# Altium schematic sheet HAQuDA_upper_PCB_schematic_Ver_2.SchDoc
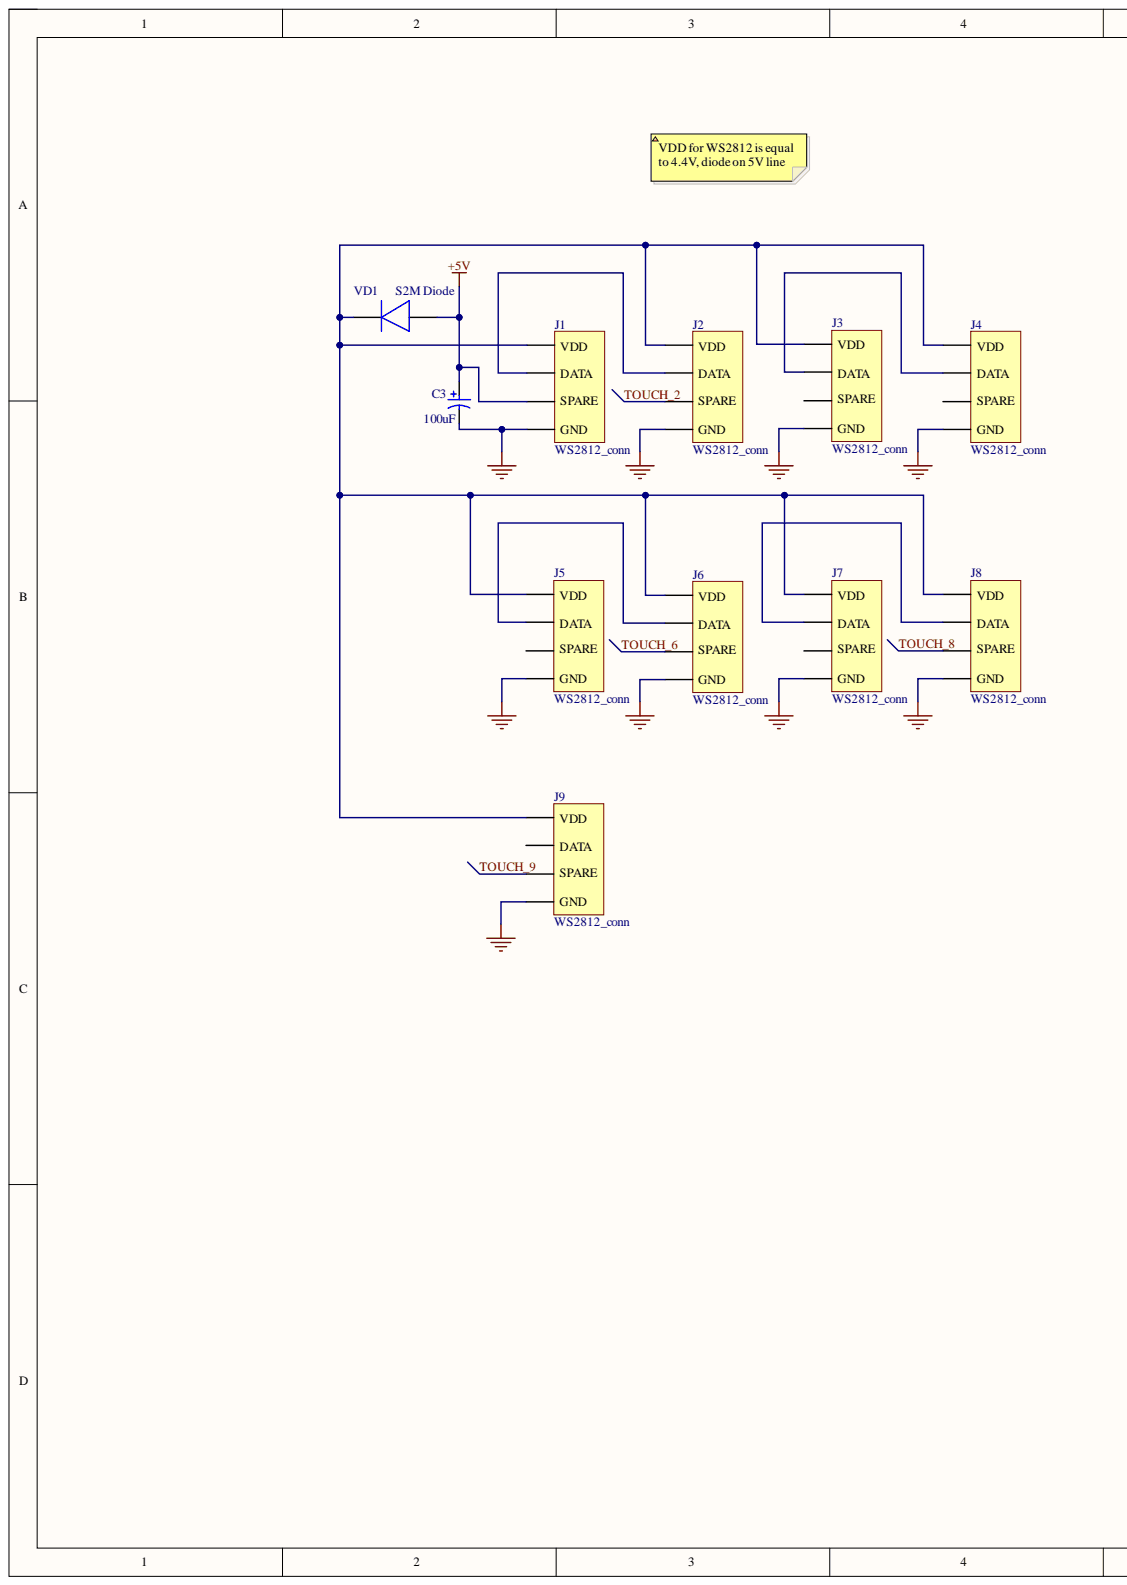
[diagram p0: HAQuDA_upper_PCB_schematic_Ver_2.SchDoc, left]
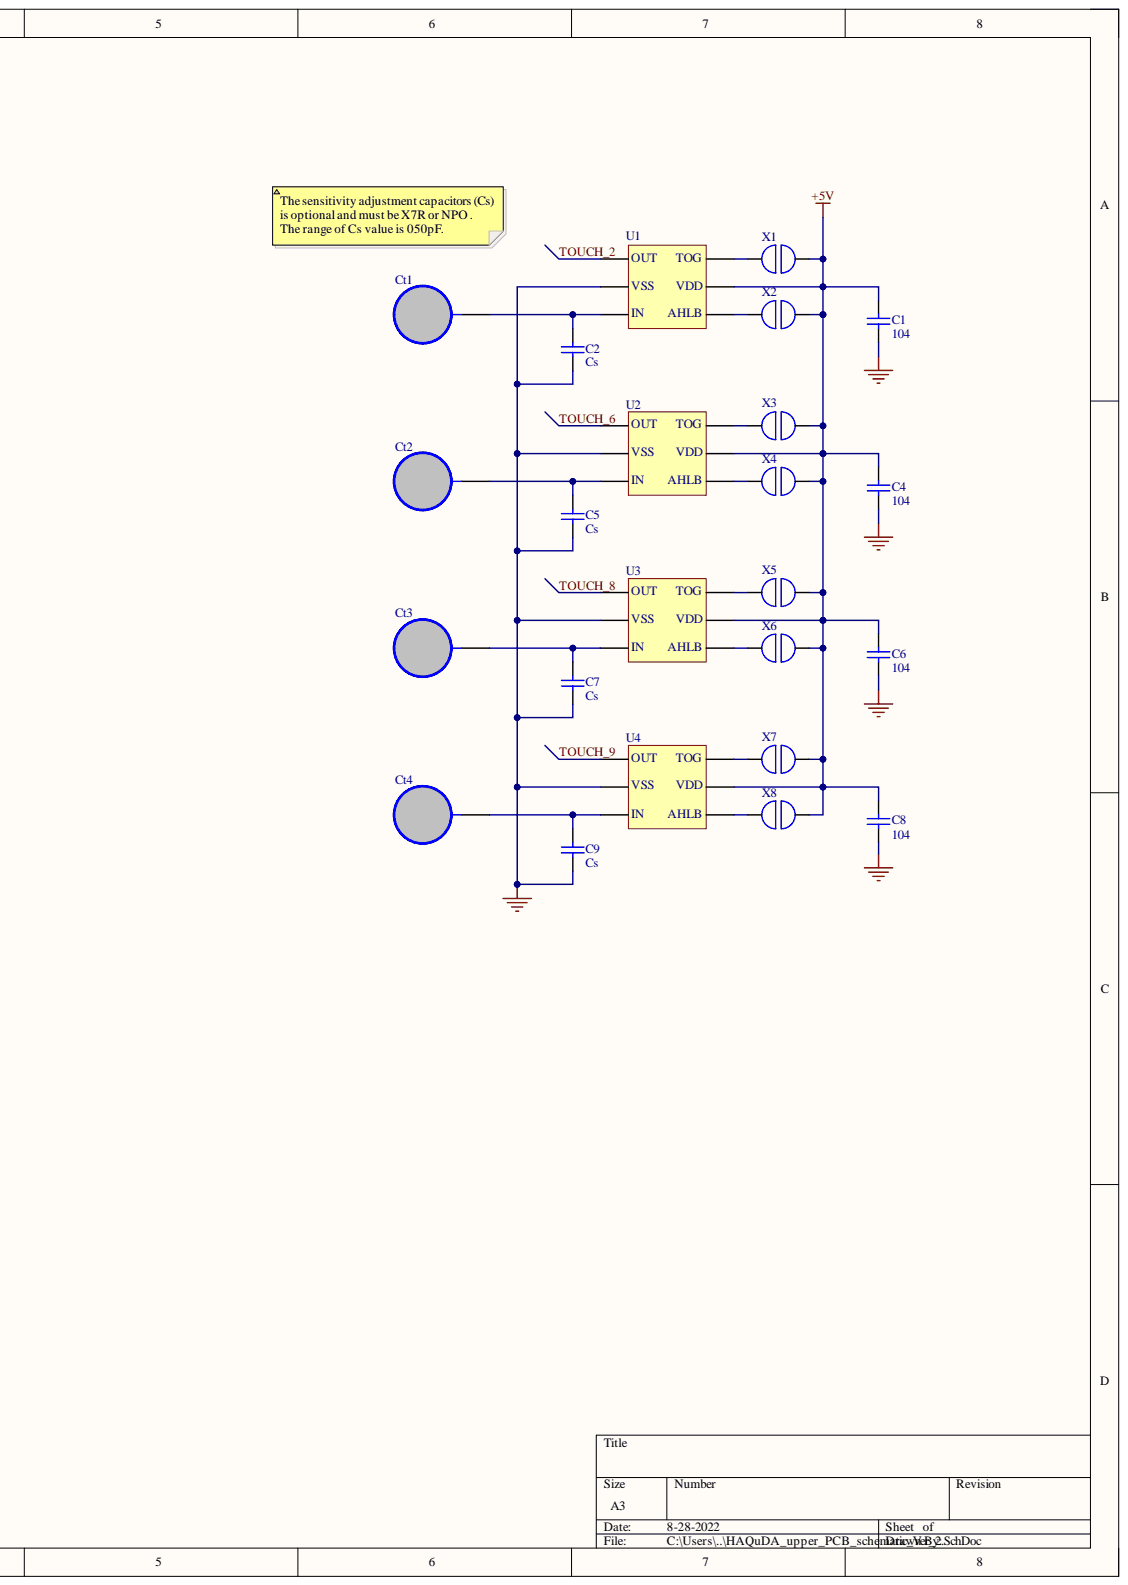
[diagram p1: HAQuDA_upper_PCB_schematic_Ver_2.SchDoc, right]

## Parts
C1  104  [CAPC2013X70X45LL10T25]
C2  Cs  [CAPC2013X70X45LL10T25]
C3  100uF  [CAPPR60-350-1500X800X1650]
C4  104  [CAPC2013X70X45LL10T25]
C5  Cs  [CAPC2013X70X45LL10T25]
C6  104  [CAPC2013X70X45LL10T25]
C7  Cs  [CAPC2013X70X45LL10T25]
C8  104  [CAPC2013X70X45LL10T25]
C9  Cs  [CAPC2013X70X45LL10T25]
Ct1  TOUCH 1  [Touch pad]  (value not drawn)
Ct2  TOUCH 6  [Touch pad]  (value not drawn)
Ct3  TOUCH 8  [Touch pad]  (value not drawn)
Ct4  TOUCH 9  [Touch pad]  (value not drawn)
J1  WS2812_conn  [WS2812_conn]
J2  WS2812_conn  [WS2812_conn]
J3  WS2812_conn  [WS2812_conn]
J4  WS2812_conn  [WS2812_conn]
J5  WS2812_conn  [WS2812_conn]
J6  WS2812_conn  [WS2812_conn]
J7  WS2812_conn  [WS2812_conn]
J8  WS2812_conn  [WS2812_conn]
J9  WS2812_conn  [WS2812_conn]
U1  Touch pad  IC  [TTP223-BA6]  (value not drawn)
U2  Touch pad  IC  [TTP223-BA6]  (value not drawn)
U3  Touch pad  IC  [TTP223-BA6]  (value not drawn)
U4  Touch pad  IC  [TTP223-BA6]  (value not drawn)
VD1  S2M Diode  [S2M Dioide ext pad]
X1  TOG  [SOLDER JUMPER]  (value not drawn)
X2  AHLB  [SOLDER JUMPER]  (value not drawn)
X3  TOG  [SOLDER JUMPER]  (value not drawn)
X4  AHLB  [SOLDER JUMPER]  (value not drawn)
X5  TOG  [SOLDER JUMPER]  (value not drawn)
X6  AHLB  [SOLDER JUMPER]  (value not drawn)
X7  TOG  [SOLDER JUMPER]  (value not drawn)
X8  AHLB  [SOLDER JUMPER]  (value not drawn)

## Nets
+5V: C1.2 C3.1 C4.2 C6.2 C8.2 J1.3(SPARE) U1.5(VDD) U2.5(VDD) U3.5(VDD) U4.5(VDD) VD1.2 X1.2 X2.2 X3.2 X4.2 X5.2 X6.2 X7.2 X8.2
GND: C1.1 C2.2 C3.2 C4.1 C5.2 C6.1 C7.2 C8.1 C9.2 J1.4(GND) J2.4(GND) J3.4(GND) J4.4(GND) J5.4(GND) J6.4(GND) J7.4(GND) J8.4(GND) J9.4(GND) U1.2(VSS) U2.2(VSS) U3.2(VSS) U4.2(VSS)
TOUCH_2: J2.3(SPARE) U1.1(OUT)
TOUCH_6: J6.3(SPARE) U2.1(OUT)
TOUCH_8: J8.3(SPARE) U3.1(OUT)
TOUCH_9: J9.3(SPARE) U4.1(OUT)
NetC2_1: C2.1 Ct1.1 U1.3(IN)
NetC5_1: C5.1 Ct2.1 U2.3(IN)
NetC7_1: C7.1 Ct3.1 U3.3(IN)
NetC9_1: C9.1 Ct4.1 U4.3(IN)
NetJ1_1: J1.1(VDD) J2.1(VDD) J3.1(VDD) J4.1(VDD) J5.1(VDD) J6.1(VDD) J7.1(VDD) J8.1(VDD) J9.1(VDD) VD1.1
NetJ1_2: J1.2(DATA) J2.2(DATA)
NetJ3_2: J3.2(DATA) J4.2(DATA)
NetJ5_2: J5.2(DATA) J6.2(DATA)
NetJ7_2: J7.2(DATA) J8.2(DATA)
NetU1_4: U1.4(AHLB) X2.1
NetU1_6: U1.6(TOG) X1.1
NetU2_4: U2.4(AHLB) X4.1
NetU2_6: U2.6(TOG) X3.1
NetU3_4: U3.4(AHLB) X6.1
NetU3_6: U3.6(TOG) X5.1
NetU4_4: U4.4(AHLB) X8.1
NetU4_6: U4.6(TOG) X7.1

Not connected: J3 3(SPARE); J4 3(SPARE); J5 3(SPARE); J7 3(SPARE); J9 2(DATA)

## Library data of the parts
C1 C2 C4-C9 = CMP-2007-00864-1: Manufacturer: Murata; Part Number: GRM2165C1H101JA01D; Component Kind: Standard; Library Name: Altium Content Vault
C3 = CMP-2000-07347-1: Aluminum Electrolytic Capacitor, 1000 uF, +/- 20%, 6.3 V, -40 to 105 degC, 2-Pin THD, RoHS, Bulk; Manufacturer: Nichicon; Capacitance: 1000µF; Rated Voltage: 6.3V; Type: Radial; Case Size/Dimension: Ø8x15mm; Component Kind: Standard; Datasheet URL: http://products.nichicon.co.jp/en/pdf/XJA043/e-uhe.pdf; Datasheet Version: 08/2015; Library Name: Altium Content Vault; Manufacturer URL: http://www.nichicon.co.jp/english/; Mounting Technology: ThroughHole; Operating Temp-Max: 105°C; Package Description: 2-Pin Through Hole Device, Dia 8 mm, Body Length 16.5 mm; Package Reference: CAPPR60-350-1500X800X1650
Ct1-Ct4 = Touch pad: Component Kind: Standard; Description: Touch pad; Library Name: HAQuDA_Upper_PCB.SchLib
J1-J9 = WS2812_conn: Connector for WS2812 PCB stack; Component Kind: Standard; Library Name: HaQuDA_Schlib.SchLib
U1-U4 = TTP223-BA6: Component Kind: Standard; Description: Touch pad  IC; Library Name: HAQuDA_Upper_PCB.SchLib
VD1 = S2M Dioide ext pad: S2M Diode with external pad for better cooling; Component Kind: Standard; Library Name: HaQuDA_Schlib.SchLib
X1-X8 = Solder jumper: Component Kind: Standard; Description: Solder jumper; Library Name: HAQuDA_Upper_PCB.SchLib
